# Altium schematic sheet SK-HC32L51-64LQFP-DAP.SchDoc
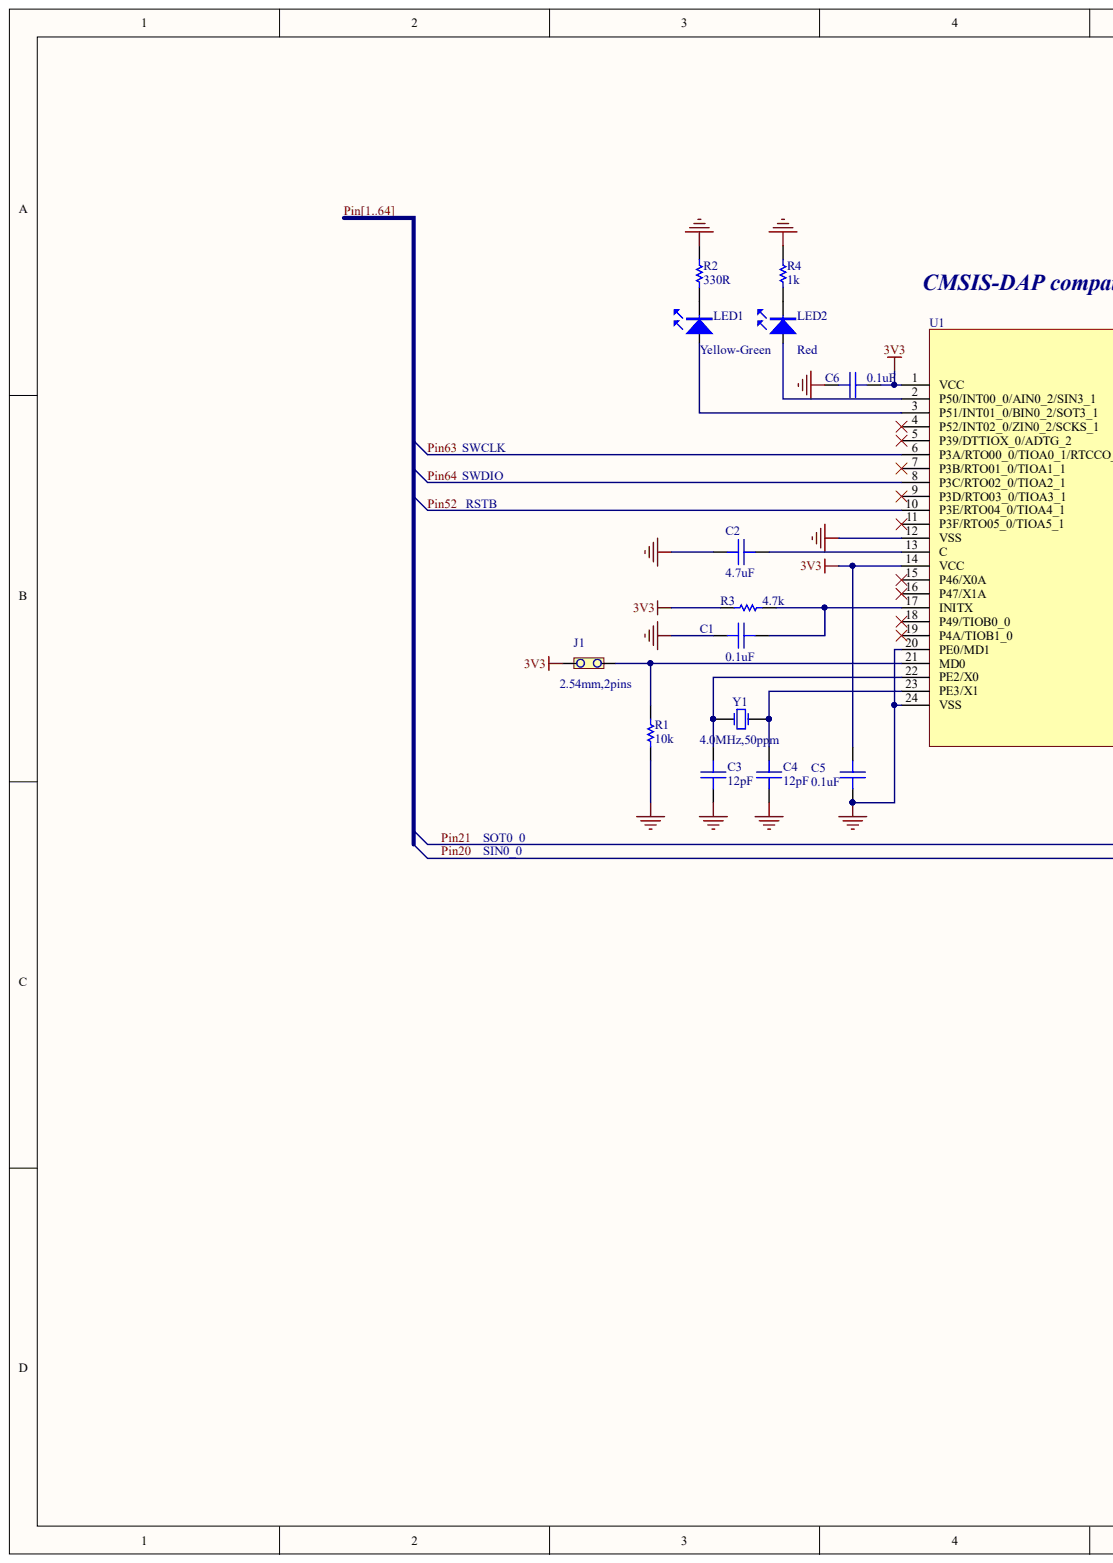
[diagram p0: SK-HC32L51-64LQFP-DAP.SchDoc, left]
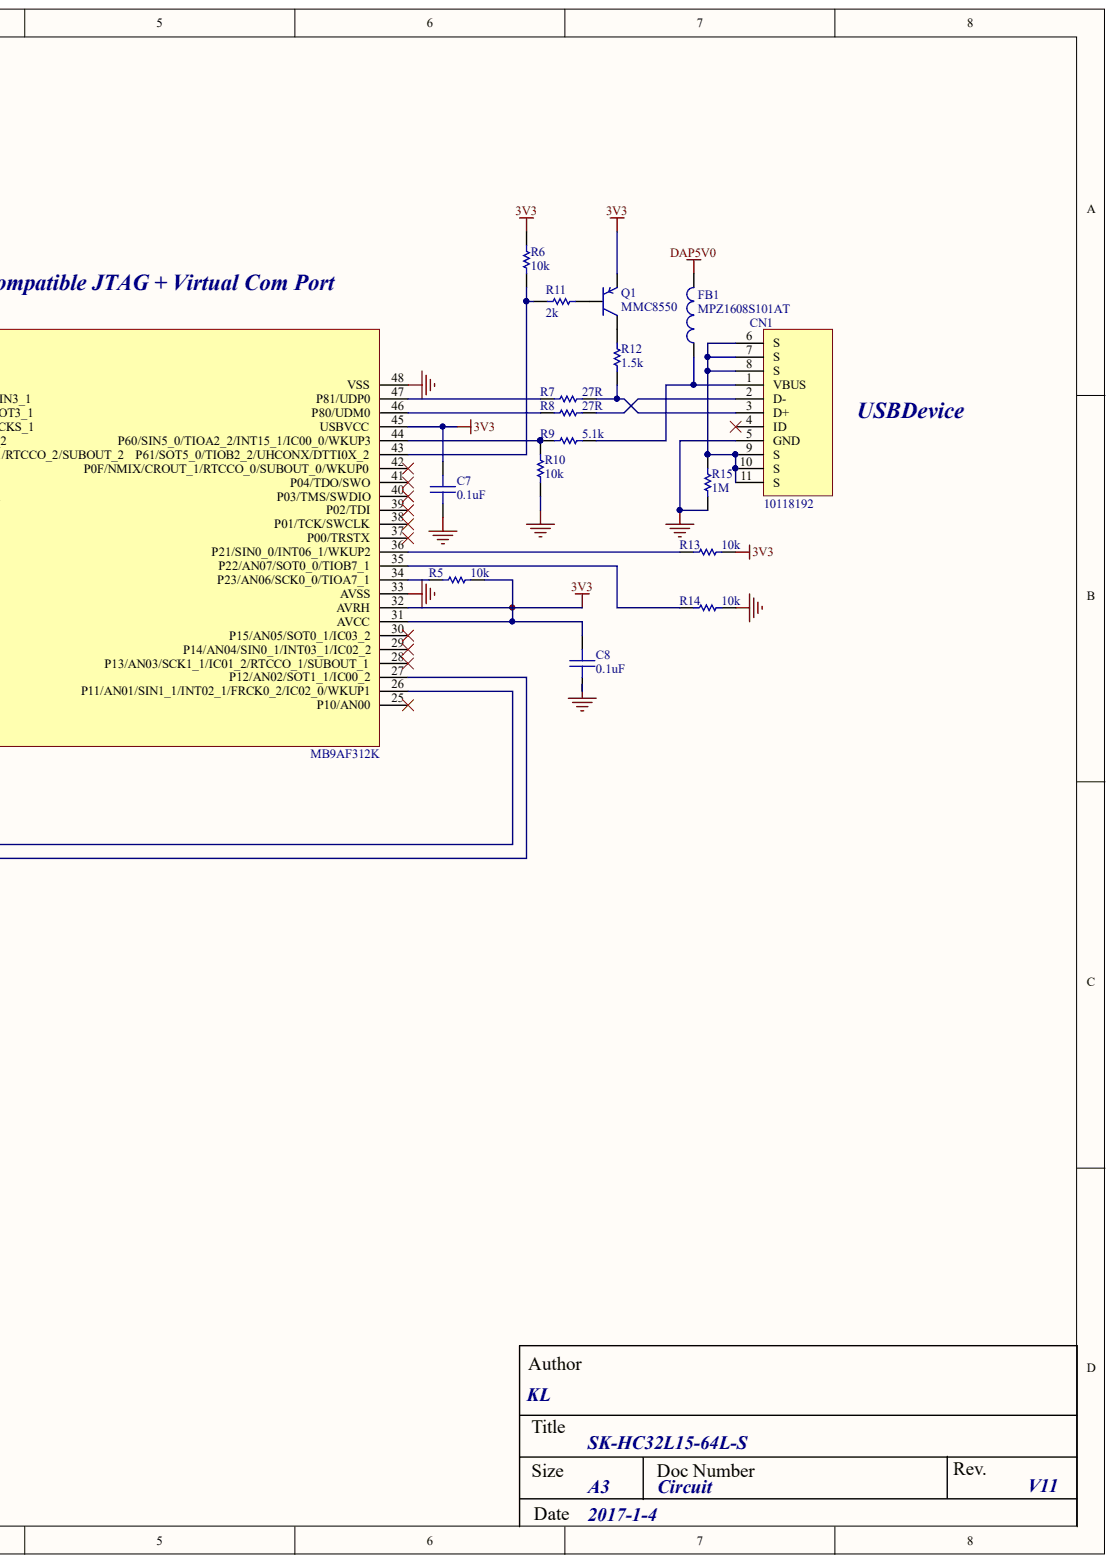
[diagram p1: SK-HC32L51-64LQFP-DAP.SchDoc, right]

## Parts
C1  0.1uF  [C0603]
C2  4.7uF  [C0805]
C3  12pF  [C0603]
C4  12pF  [C0603]
C5  0.1uF  [C0603]
C6  0.1uF  [C0603]
C7  0.1uF  [C0603]
C8  0.1uF  [C0603]
CN1  10118192  [Micro-USB-B-1]
FB1  MPZ1608S101AT  [R0603]
J1  2.54mm,2pins  [PIN-2-254-S]
LED1  Yellow-Green  [LED0603]
LED2  Red  [LED0603]
Q1  MMC8550  [SOT-23]
R1  10k  [R0603]
R2  330R  [R0603]
R3  4.7k  [R0603]
R4  1k  [R0603]
R5  10k  [R0603]
R6  10k  [R0603]
R7  27R  [R0603]
R8  27R  [R0603]
R9  5.1k  [R0603]
R10  10k  [R0603]
R11  2k  [R0603]
R12  1.5k  [R0603]
R13  10k  [R0603]
R14  10k  [R0603]
R15  1M  [R0603]
U1  MB9AF312K  [LQFP-48-070-050]
Y1  4.0MHz,50ppm  [49s]

## Nets
3V3: C5.1 C6.2 C7.2 C8.2 J1.2 Q1.2 R3.2 R5.2 R6.1 R13.1 U1.1(VCC) U1.14(VCC) U1.31(AVCC) U1.32(AVRH) U1.45(USBVCC)
DAP5V0: FB1.2
GND: C1.1 C2.2 C3.2 C4.2 C5.2 C6.1 C7.1 C8.1 CN1.5(GND) R1.2 R2.2 R4.1 R10.2 R14.1 R15.1 U1.12(VSS) U1.20(PE0/MD1) U1.24(VSS) U1.33(AVSS) U1.48(VSS)
PIN20: U1.27(P12/AN02/SOT1_1/IC00_2)  [also labelled PIN]
PIN21: U1.26(P11/AN01/SIN1_1/INT02_1/FRCK0_2/IC02_0/WKUP1)  [also labelled PIN]
PIN52: U1.10(P3E/RTO04_0/TIOA4_1)  [also labelled PIN]
PIN63: U1.6(P3A/RTO00_0/TIOA0_1/RTCCO_2/SUBOUT_2)  [also labelled PIN]
PIN64: U1.8(P3C/RTO02_0/TIOA2_1)  [also labelled PIN]
NetC1_2: C1.2 R3.1 U1.17(INITX)
NetC2_1: C2.1 U1.13(C)
NetC3_1: C3.1 U1.22(PE2/X0) Y1.1
NetC4_1: C4.1 U1.23(PE3/X1) Y1.2
NetCN1_1: CN1.1(VBUS) FB1.1 R9.1
NetCN1_2: CN1.2(D-) R8.1
NetCN1_3: CN1.3(D+) R7.1 R12.2
NetCN1_6: CN1.6(S) CN1.7(S) CN1.8(S) CN1.9(S) CN1.10(S) CN1.11(S) R15.2
NetJ1_1: J1.1 R1.1 U1.21(MD0)
NetLED1_1: LED1.1 U1.3(P51/INT01_0/BIN0_2/SOT3_1)
NetLED1_2: LED1.2 R2.1
NetLED2_1: LED2.1 U1.2(P50/INT00_0/AIN0_2/SIN3_1)
NetLED2_2: LED2.2 R4.2
NetQ1_1: Q1.1 R11.2
NetQ1_3: Q1.3 R12.1
NetR5_1: R5.1 U1.34(P23/AN06/SCK0_0/TIOA7_1)
NetR6_2: R6.2 R11.1 U1.43(P61/SOT5_0/TIOB2_2/UHCONX/DTTI0X_2)
NetR7_2: R7.2 U1.47(P81/UDP0)
NetR8_2: R8.2 U1.46(P80/UDM0)
NetR9_2: R9.2 R10.1 U1.44(P60/SIN5_0/TIOA2_2/INT15_1/IC00_0/WKUP3)
NetR13_2: R13.2 U1.36(P21/SIN0_0/INT06_1/WKUP2)
NetR14_2: R14.2 U1.35(P22/AN07/SOT0_0/TIOB7_1)
PIN1:  [also labelled PIN]
PIN2:  [also labelled PIN]
PIN3:  [also labelled PIN]
PIN4:  [also labelled PIN]
PIN5:  [also labelled PIN]
PIN6:  [also labelled PIN]
PIN7:  [also labelled PIN]
PIN8:  [also labelled PIN]
PIN9:  [also labelled PIN]
PIN10:  [also labelled PIN]
PIN11:  [also labelled PIN]
PIN12:  [also labelled PIN]
PIN13:  [also labelled PIN]
PIN14:  [also labelled PIN]
PIN15:  [also labelled PIN]
PIN16:  [also labelled PIN]
PIN17:  [also labelled PIN]
PIN18:  [also labelled PIN]
PIN19:  [also labelled PIN]
PIN22:  [also labelled PIN]
PIN23:  [also labelled PIN]
PIN24:  [also labelled PIN]
PIN25:  [also labelled PIN]
PIN26:  [also labelled PIN]
PIN27:  [also labelled PIN]
PIN28:  [also labelled PIN]
PIN29:  [also labelled PIN]
PIN30:  [also labelled PIN]
PIN31:  [also labelled PIN]
PIN32:  [also labelled PIN]
PIN33:  [also labelled PIN]
PIN34:  [also labelled PIN]
PIN35:  [also labelled PIN]
PIN36:  [also labelled PIN]
PIN37:  [also labelled PIN]
PIN38:  [also labelled PIN]
PIN39:  [also labelled PIN]
PIN40:  [also labelled PIN]
PIN41:  [also labelled PIN]
PIN42:  [also labelled PIN]
PIN43:  [also labelled PIN]
PIN44:  [also labelled PIN]
PIN45:  [also labelled PIN]
PIN46:  [also labelled PIN]
PIN47:  [also labelled PIN]
PIN48:  [also labelled PIN]
PIN49:  [also labelled PIN]
PIN50:  [also labelled PIN]
PIN51:  [also labelled PIN]
PIN53:  [also labelled PIN]
PIN54:  [also labelled PIN]
PIN55:  [also labelled PIN]
PIN56:  [also labelled PIN]
PIN57:  [also labelled PIN]
PIN58:  [also labelled PIN]
PIN59:  [also labelled PIN]
PIN60:  [also labelled PIN]
PIN61:  [also labelled PIN]
PIN62:  [also labelled PIN]

Not connected: CN1 4(ID); U1 4(P52/INT02_0/ZIN0_2/SCKS_1) 5(P39/DTTIOX_0/ADTG_2) 7(P3B/RTO01_0/TIOA1_1) 9(P3D/RTO03_0/TIOA3_1) 11(P3F/RTO05_0/TIOA5_1) 15(P46/X0A) 16(P47/X1A) 18(P49/TIOB0_0) 19(P4A/TIOB1_0) 25(P10/AN00) 28(P13/AN03/SCK1_1/IC01_2/RTCCO_1/SUBOUT_1) 29(P14/AN04/SIN0_1/INT03_1/IC02_2) 30(P15/AN05/SOT0_1/IC03_2) 37(P00/TRSTX) 38(P01/TCK/SWCLK) 39(P02/TDI) 40(P03/TMS/SWDIO) 41(P04/TDO/SWO) 42(P0F/NMIX/CROUT_1/RTCCO_0/SUBOUT_0/WKUP0)

## Library data of the parts
C1-C8 = C_no pol: Capacitor without polarity; Component Kind: Standard; Library Name: FUJITSU-SK.SCHLIB
CN1 = Micro USB- B: Micro USB-B type, TE; Component Kind: Standard; Library Name: MyLib_Altium.SCHLIB
FB1 = Inductor: Ferrite bead, 3A,100R@100MHz,TDK; Code_IEC: 1005; Code_JEITA: 0402; Component Kind: Standard; LatestRevisionDate: 17-Jul-2002; LatestRevisionNote: Re-released for DXP Platform.; Library Name: MyLib_Altium.SCHLIB; PackageReference: 0402-A; Published: 8-Jun-2000; Signal Integrity: Inductor; Simulation: INDUCTOR; Publisher: Altium Limited
J1 = Jumper-2: Jumper Wire; Component Kind: Standard; LatestRevisionDate: 17-Jul-2002; LatestRevisionNote: Re-released for DXP Platform.; Library Name: MyLib_Altium.SCHLIB; PackageReference: RAD-0.2; Published: 24-Mar-1999; Publisher: Altium Limited
LED1 LED2 = LED: Component Kind: Standard; Library Name: MyLib_Altium.SCHLIB
Q1 = T_PNP: PNP transistor; Component Kind: Standard; Library Name: FUJITSU-SK.SCHLIB
R1 R5 R6 R10 R13 R14 = Res1: Resistor; Component Kind: Standard; LatestRevisionDate: 17-Jul-2002; LatestRevisionNote: Re-released for DXP Platform.; Library Name: GD.SchLib; PackageReference: AXIAL-0.3; Published: 8-Jun-2000; Simulation: RESISTOR; Value: 10K; Publisher: Altium Limited
R2-R4 R7-R9 R11 R12 R15 = Res1: Resistor; Value: 10K; Component Kind: Standard; LatestRevisionDate: 17-Jul-2002; LatestRevisionNote: Re-released for DXP Platform.; Library Name: GD.SchLib; PackageReference: AXIAL-0.3; Published: 8-Jun-2000; Simulation: RESISTOR; Publisher: Altium Limited
U1 = MB9AF314KPMC/QN_1: MB9AF314KPMC/QN 48 Pin two-sided; Component Kind: Standard; Library Name: FUJITSU-SK.SCHLIB
Y1 = Crystal: 4.0000MHz; Component Kind: Standard; Library Name: MyLib_Altium.SCHLIB
